# Altium schematic sheet lv_power_motor.SchDoc(lv_power_motor)
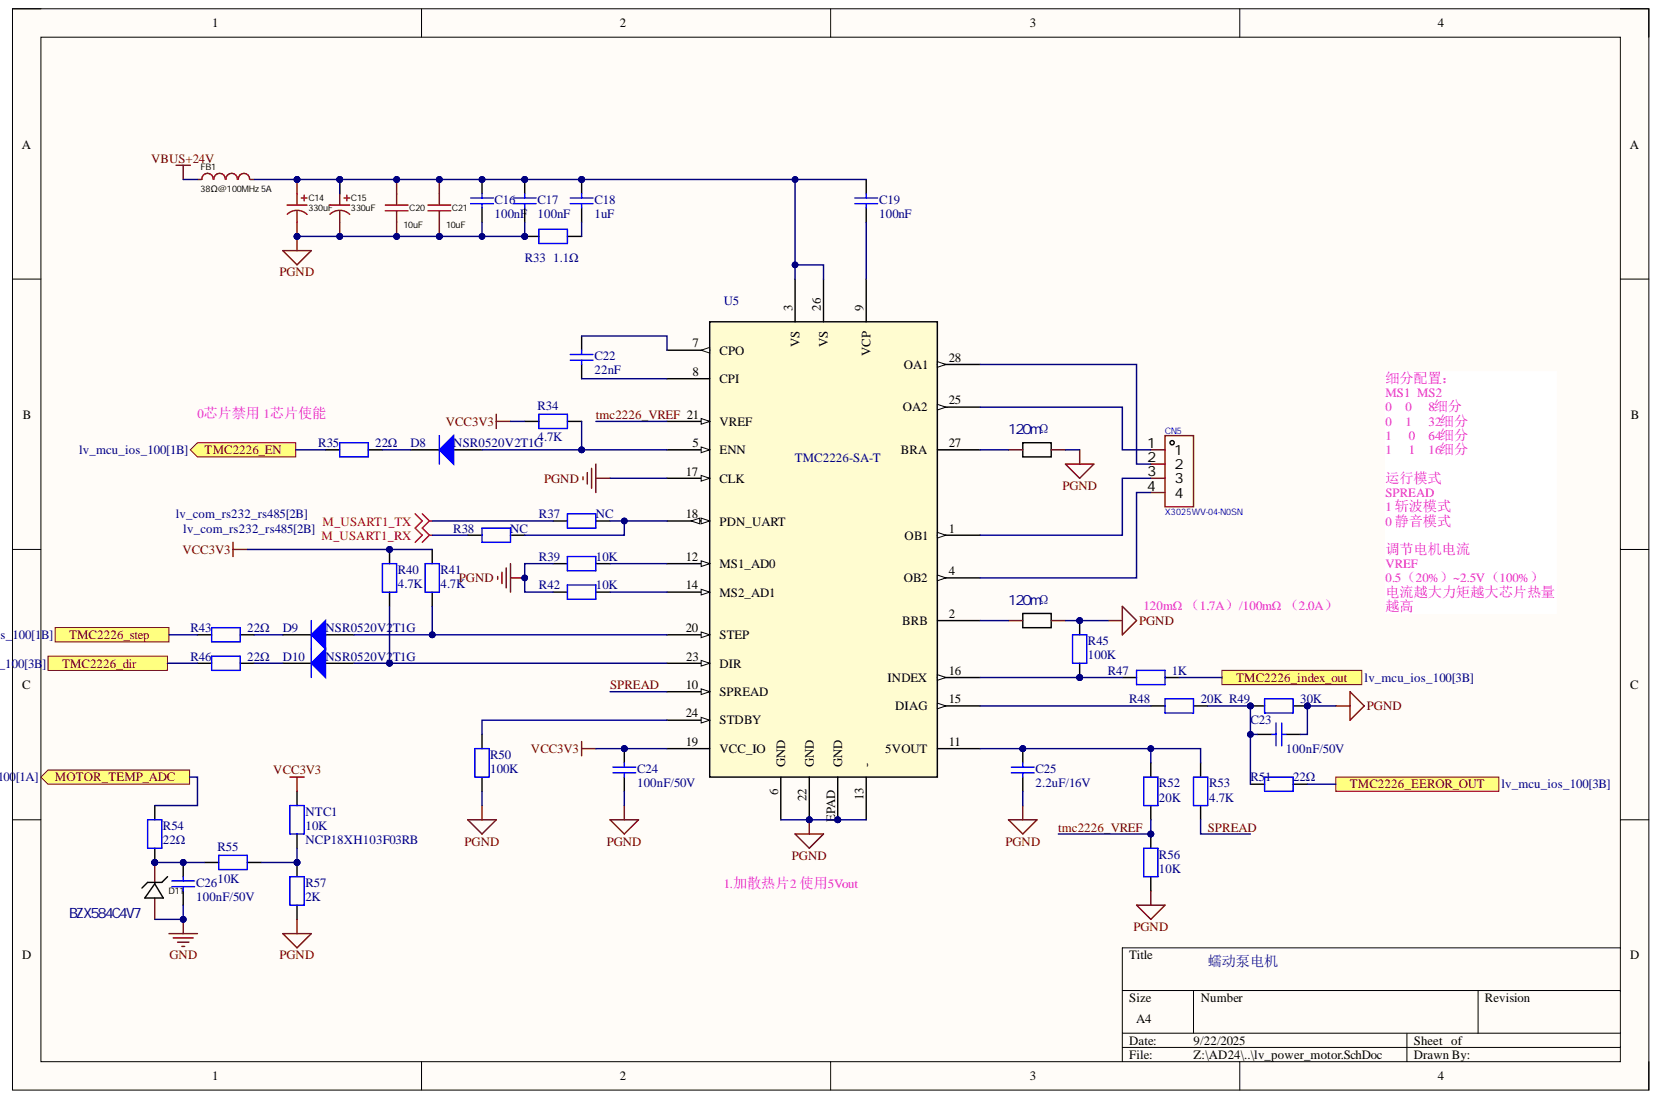
[diagram p0: lv_power_motor.SchDoc]

## Parts
C14  330uF  [CAP-SMD_BD10.0-L10.3-W10.3-LS10.9-FD]
C15  330uF  [CAP-SMD_BD10.0-L10.3-W10.3-LS10.9-FD]
C16  100nF  [C0603]
C17  100nF  [C0603]
C18  1uF  [C1210]
C19  100nF  [C0603]
C20  10uF  [C2220]
C21  10uF  [C2220]
C22  22nF  [C0603]
C23  100nF/50V  [C0402]
C24  100nF/50V  [C0402]
C25  2.2uF/16V  [C0603]
C26  100nF/50V  [C0402]
CN5  X3025WV-04-N0SN  [CONN-TH_4P-P3.00_X3025WV-04-N0SN]
D8  NSR0520V2T1G  [SOD-523F_L1.2-W0.8-LS1.7-RD]
D9  NSR0520V2T1G  [SOD-523F_L1.2-W0.8-LS1.7-RD]
D10  NSR0520V2T1G  [SOD-523F_L1.2-W0.8-LS1.7-RD]
D11  BZX584C4V7  [SOD-523F_L1.2-W0.8-LS1.7-RD]
FB1  LCSC Part Name: 38Ω@100MHz 5A  [L1206]  (hidden comment: PZ3216D380-5R0TF)
NTC1  NCP18XH103F03RB  value 10K  [R0603]
R33  1.1Ω  [R0603]
R34  4.7K  [R0603]
R35  22Ω  [R0402]
R36  120mΩ  [RES-SMD_L6.4-W3.2_C500733]
R37  NC  [R0402]
R38  NC  [R0402]
R39  10K  [R0402]
R40  4.7K  [R0402]
R41  4.7K  [R0402]
R42  10K  [R0402]
R43  22Ω  [R0402]
R44  120mΩ  [RES-SMD_L6.4-W3.2_C500733]
R45  100K  [R0402]
R46  22Ω  [R0402]
R47  1K  [R0402]
R48  20K  [R0402]
R49  30K  [R0402]
R50  100K  [R0603]
R51  22Ω  [R0402]
R52  20K  [R0402]
R53  4.7K  [R0402]
R54  22Ω  [R0402]
R55  10K  [R0402]
R56  10K  [R0402]
R57  2K  [R0402]
U5  TMC2226-SA-T  [HTSSOP_26-SA-T_TRI]

## Ports
MOTOR_TEMP_ADC, TMC2226_dir, TMC2226_EEROR_OUT, TMC2226_EN, TMC2226_index_out, TMC2226_step

## Nets
GND: C26.2 D11.2
M_USART1_RX: R38.2
M_USART1_TX: R37.2
PGND: C14.2 C15.2 C16.1 C17.1 C20.2 C21.2 C23.2 C24.2 C25.2 R33.2 R36.2 R39.1 R42.1 R44.2 R45.1 R49.1 R50.1 R56.2 R57.1 U5.6(GND) U5.13(-) U5.17(CLK) U5.22(GND) U5.EPAD(GND)
VBUS+24V: FB1.1
VCC3V3: C24.1 NTC1.2 R34.2 R40.1 R41.1 U5.19(VCC_IO)
MOTOR_TEMP_ADC: R54.2 ; port MOTOR_TEMP_ADC
SPREAD: R53.2 U5.10(SPREAD)
TMC2226_dir: R46.1 ; port TMC2226_dir
TMC2226_EEROR_OUT: R51.2 ; port TMC2226_EEROR_OUT
TMC2226_EN: R35.1 ; port TMC2226_EN
TMC2226_index_out: R47.1 ; port TMC2226_index_out
TMC2226_step: R43.1 ; port TMC2226_step
tmc2226_VREF: R52.2 R56.1 U5.21(VREF)
NetC14_1: C14.1 C15.1 C16.2 C17.2 C18.2 C19.2 C20.1 C21.1 FB1.2 U5.3(VS) U5.26(VS)
NetC18_1: C18.1 R33.1
NetC19_1: C19.1 U5.9(VCP)
NetC22_1: C22.1 U5.8(CPI)
NetC22_2: C22.2 U5.7(CPO)
NetC23_1: C23.1 R48.1 R49.2 R51.1
NetC25_1: C25.1 R52.1 R53.1 U5.11(5VOUT)
NetC26_1: C26.1 D11.1 R54.1 R55.2
NetCN5_1: CN5.1 U5.25(OA2)
NetCN5_2: CN5.2 U5.28(OA1)
NetCN5_3: CN5.3 U5.1(OB1)
NetCN5_4: CN5.4 U5.4(OB2)
NetD8_1: D8.1 R35.2
NetD8_2: D8.2 R34.1 U5.5(ENN)
NetD9_1: D9.1 R43.2
NetD9_2: D9.2 R41.2 U5.20(STEP)
NetD10_1: D10.1 R46.2
NetD10_2: D10.2 R40.2 U5.23(DIR)
NetNTC1_1: NTC1.1 R55.1 R57.2
NetR36_1: R36.1 U5.27(BRA)
NetR37_1: R37.1 R38.1 U5.18(PDN_UART)
NetR39_2: R39.2 U5.12(MS1_AD0)
NetR42_2: R42.2 U5.14(MS2_AD1)
NetR44_1: R44.1 U5.2(BRB)
NetR45_2: R45.2 R47.2 U5.16(INDEX)
NetR48_2: R48.2 U5.15(DIAG)
NetR50_2: R50.2 U5.24(STDBY)

## Library data of the parts
C14 C15 = HV1V337M1010PZ: 330uF, 35V, 20%; Manufacturer: HONOR(荣誉); Add into BOM: yes; L: 10mm; Component Kind: Standard; Convert to PCB: yes; Datasheet: https://atta.szlcsc.com/upload/public/pdf/source/20240802/61683F5000C7CFED5606ABBE3CFBE0F5.pdf; Device: HV1V337M1010PZ; JLCPCB Part Class: Extended Part; LCSC Part Name: 330uF ±20% 35V; Library Name: HiPin_Library_AD.IntLib; Manufacturer Part: HV1V337M1010PZ; Operating Temperature: -55℃~+105℃; Rated Voltage: 35V; Supplier: LCSC; Supplier Footprint: SMD,D10xL10mm; Supplier Part: C5246558; Symbol: HV1V337M1010PZ; Tolerance: ±20%; Value: 330uF; φD: 10mm
C16 C17 C19 = Capacitor_1: 50V, X7R, 10%; Manufacturer: muRata; Manufacturer_Part_Number: GRM188R71H104KA93D; Component Kind: Standard; ComponentLink1Description: Yageo_Capacitor_X5R-series.pdf; ComponentLink1URL: http://tunmcdsvn.tun.st.com/Component_Datasheet_Library/Trunk/Capacitors/Yageo_Multilayer_capacitor_X5R_series_100pF_to_100uF; Library Name: HiPin_Library_AD.IntLib
C18 = Capacitor_3: 50V, X7R, 10%; Manufacturer: YAGEO; Manufacturer_Part_Number: CC1210KKX7R9BB105; Component Kind: Standard; Library Name: HiPin_Library_AD.IntLib
C20 C21 = FS55X106K500EGG: Manufacturer: PSA(信昌电陶); Add into BOM: yes; Temperature Coefficient: X7R; Tolerance: ±10%; Voltage Rated: 50V; Component Kind: Standard; Convert to PCB: yes; Datasheet: https://atta.szlcsc.com/upload/public/pdf/source/20190723/C381466_B1CCDB289216E7EE775C98769E222CFD.pdf; Device: FS55X106K500EGG; JLCPCB Part Class: Extended Part; LCSC Part Name: 10uF ±10% 50V; Library Name: HiPin_Library_AD.IntLib; Manufacturer Part: FS55X106K500EGG; Supplier: LCSC; Supplier Footprint: 2220; Supplier Part: C153034; Symbol: FS55X106K500EGG; Value: 10uF
C22 = Capacitor_1: 50V, X7R, 10%; Manufacturer: muRata; Manufacturer_Part_Number: GCJ188R71H223KA01D; Component Kind: Standard; ComponentLink1Description: Yageo_Capacitor_X5R-series.pdf; ComponentLink1URL: http://tunmcdsvn.tun.st.com/Component_Datasheet_Library/Trunk/Capacitors/Yageo_Multilayer_capacitor_X5R_series_100pF_to_100uF; Library Name: HiPin_Library_AD.IntLib
C23 C24 C26 = Capacitor_2: 50V, X7R , 10%; Manufacturer: muRata; Manufacturer_Part_Number: GRM155R71H104KE14D; Component Kind: Standard; ComponentLink1Description: Datasheet; ComponentLink1URL: http://tunmcdsvn.tun.st.com/Component_Datasheet_Library/Trunk/Capacitors/Yageo_Multilayer_capacitor_X5R_series_100pF_to_100uF; Library Name: HiPin_Library_AD.IntLib
C25 = Capacitor_2: 16V, X7R , 10%; Manufacturer: YAGEO; Manufacturer_Part_Number: CC0603KRX7R7BB225; Component Kind: Standard; ComponentLink1Description: Datasheet; ComponentLink1URL: http://tunmcdsvn.tun.st.com/Component_Datasheet_Library/Trunk/Capacitors/Yageo_Multilayer_capacitor_X5R_series_100pF_to_100uF; Library Name: HiPin_Library_AD.IntLib
CN5 = X3025WV-04-N0SN: 插针结构1x4P,间距3mm.安装方式直插  配套:针座X3025WV-04-N0SN ,胶壳X3025HM-04-N2 ,端子X3025TF-PSN; Manufacturer: XKB Connection(中国星坤); Add into BOM: yes; Current Rating: 5A; Number of PINs Per Row: 4; Pins Structure: 1x4P; Pitch: 3mm; Component Kind: Standard; Contact Material: 黄铜; Contact Plating: 锡; Convert to PCB: yes; Datasheet: https://item.szlcsc.com/datasheet/X3025WV-04-N0SN/639847.html; Device: X3025WV-04-N0SN; JLCPCB Part Class: Extended Part; LCSC Part Name: 1x4P 间距:3mm 直插; Library Name: HiPin_Library_AD.IntLib; Manufacturer Part: X3025WV-04-N0SN; Mounting Type: 直插; Number of Pins: 4P; Number of Rows: 1; Operating Temperature: -; PCB3D: CONN-TH_4P-P3.00_X3025WV-04-N0SN; Reference Series: -; Row Spacing: -; Supplier: LCSC; Supplier Footprint: 插件,P=3mm; Supplier Part: C609842; Symbol: X3025WV-04-N0SN
D8-D10 = 1N4148: Component Kind: Standard; Library Name: HiPin_Library_AD.IntLib
D11 = LM5Z3V6T1G: 4.8~5.4V, 200mW; Power Dissipation: 500mW; Component Kind: Standard; Library Name: HiPin_Library_AD.IntLib; Manufacturer Part: BZX584C4V7; Supplier Footprint: SOD-123; Tolerance: -
FB1 = BLM31PG601SN1L: Manufacturer: Sunlord(顺络); Add into BOM: yes; Circuits: 1; Current Rating: 1.5A; DC Resistance: 80mΩ; Impedance @ Frequency: 600Ω@100MHz; Tolerance: ±25%; Component Kind: Standard; Convert to PCB: yes; Datasheet: https://atta.szlcsc.com/upload/public/pdf/source/20180326/C88997_FE7208E239BA92CF7577D85CF2703D30.pdf; Device: BLM31PG601SN1L; JLCPCB Part Class: Extended Part; Library Name: HiPin_Library_AD.IntLib; Manufacturer Part: PZ3216D380-5R0TF; Supplier: LCSC; Supplier Footprint: 1206; Supplier Part: C16902; Symbol: BLM31PG601SN1L
NTC1 = RES: 1% 3380K; Component Kind: Standard; Library Name: HiPin_Library_AD.IntLib
R33-R35 R37 R38 R40 R41 R43 R45-R54 R57 = RES: 1%; Value: 10K; Component Kind: Standard; Library Name: HiPin_Library_AD.IntLib
R36 R44 = PA2512FKE070R005E: 120mΩ，1W，1%; Manufacturer: YAGEO(国巨); Manufacturer Part: RL2512JK-070R12L; Component Kind: Standard; Library Name: HiPin_Library_AD.IntLib; PCB3D: RES-SMD_L6.4-W3.2_C500733
R39 R42 R55 R56 = RES: 1%; Component Kind: Standard; Library Name: HiPin_Library_AD.IntLib; Value: 10K
U5 = TMC2226-SA-T: No Description Available; Mfr_Name: Analog Devices Inc; Component Kind: Standard; Library Name: HiPin_Library_AD.IntLib; RefDes: RefDes; Type: Type
